# Altium schematic sheet 1-Connectors.SchDoc(1-Connectors)
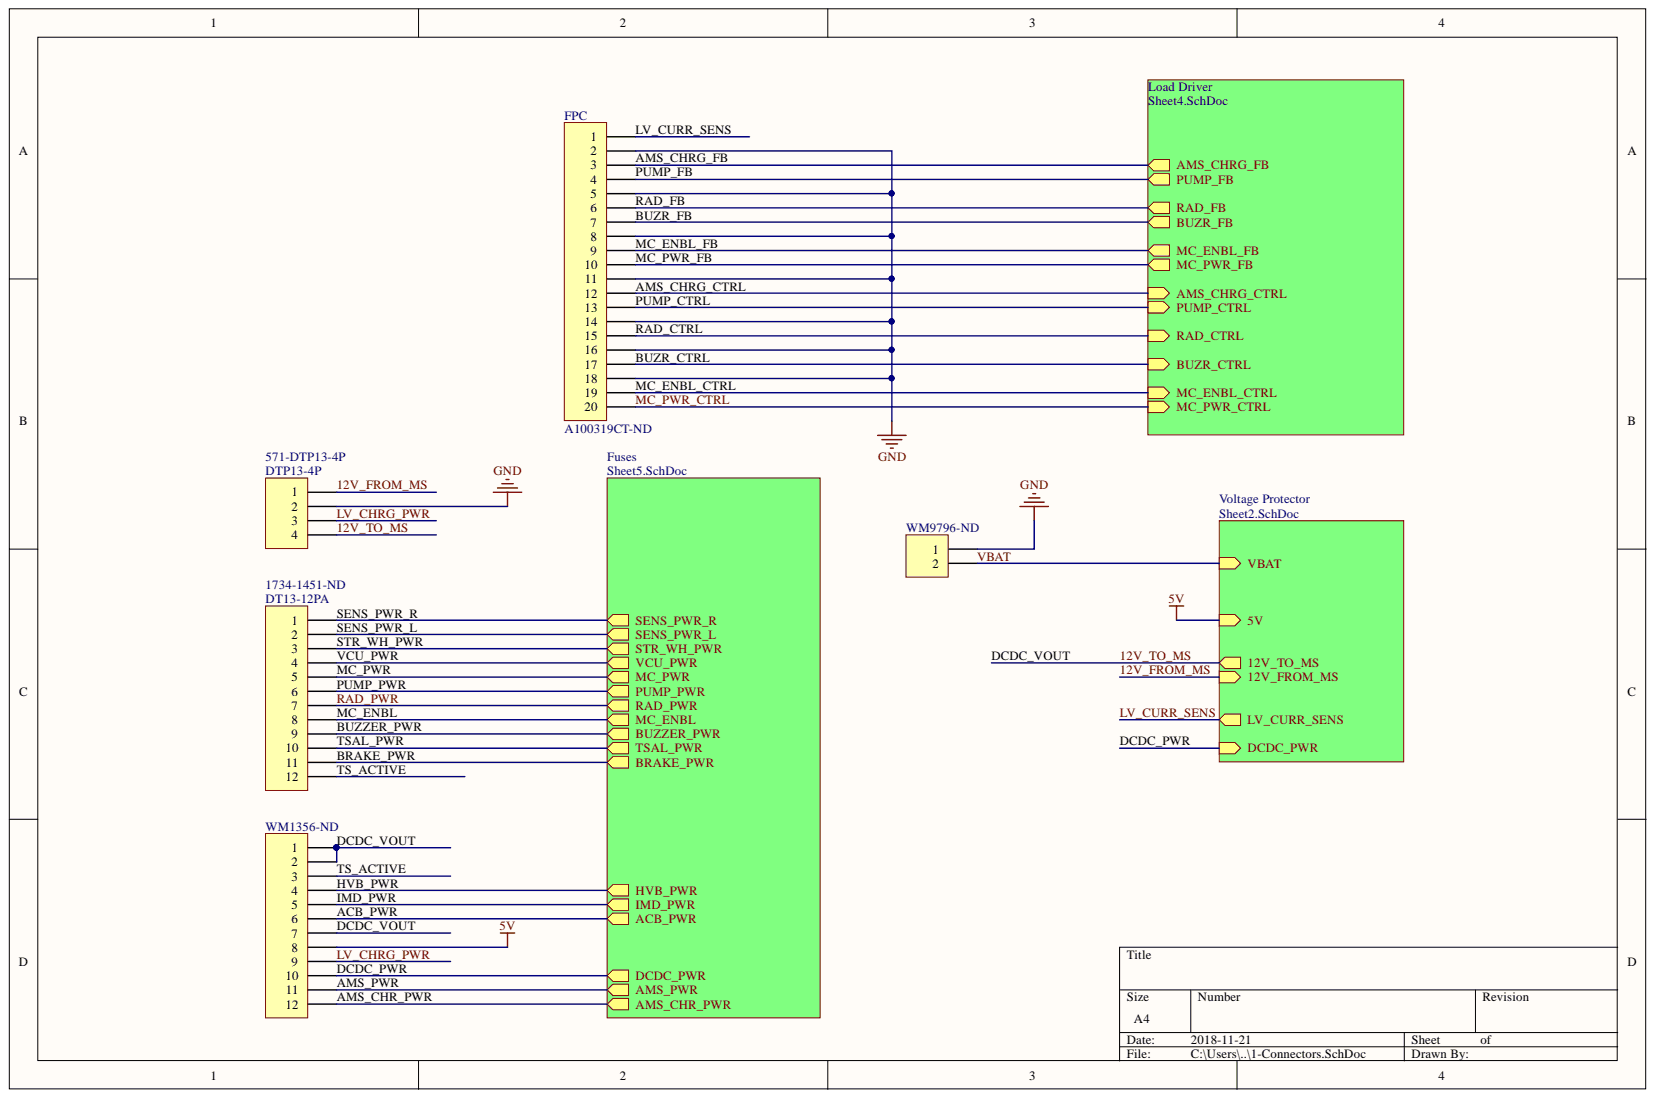
[diagram p0: 1-Connectors.SchDoc]

## Parts
DT13-12PA  DT13-12PA  Supplier Part Number 2: 1734-1451-ND  [DT13-12PA]
DTP13-4P  DTP13-4P  Supplier Part Number 1: 571-DTP13-4P  [DTP13-4P]
FPC  Header 20  [A100319CT-ND]  (value not drawn)
WM1356-ND  Header 12  [WM1356-ND]  (value not drawn)
WM9796-ND  WM9796-ND  [WM9796-ND]

## Nets
5V: WM1356-ND.8
GND: DTP13-4P.2 FPC.2 FPC.5 FPC.8 FPC.11 FPC.14 FPC.16 FPC.18 WM9796-ND.1
12V_FROM_MS: DTP13-4P.1
12V_TO_MS: DTP13-4P.4 WM1356-ND.1 WM1356-ND.2 WM1356-ND.7
ACB_PWR: WM1356-ND.6
AMS_CHR_PWR: WM1356-ND.12
AMS_CHRG_CTRL: FPC.12
AMS_CHRG_FB: FPC.3
AMS_PWR: WM1356-ND.11
BRAKE_PWR: DT13-12PA.11
BUZR_CTRL: FPC.17
BUZR_FB: FPC.7
BUZZER_PWR: DT13-12PA.9
DCDC_PWR: WM1356-ND.10
HVB_PWR: WM1356-ND.4
IMD_PWR: WM1356-ND.5
LV_CHRG_PWR: DTP13-4P.3 WM1356-ND.9
LV_CURR_SENS: FPC.1
MC_ENBL: DT13-12PA.8
MC_ENBL_CTRL: FPC.19
MC_ENBL_FB: FPC.9
MC_PWR: DT13-12PA.5
MC_PWR_CTRL: FPC.20
MC_PWR_FB: FPC.10
PUMP_CTRL: FPC.13
PUMP_FB: FPC.4
PUMP_PWR: DT13-12PA.6
RAD_CTRL: FPC.15
RAD_FB: FPC.6
RAD_PWR: DT13-12PA.7
SENS_PWR_L: DT13-12PA.2
SENS_PWR_R: DT13-12PA.1
STR_WH_PWR: DT13-12PA.3
TS_ACTIVE: DT13-12PA.12 WM1356-ND.3
TSAL_PWR: DT13-12PA.10
VBAT: WM9796-ND.2
VCU_PWR: DT13-12PA.4

## Library data of the parts
DT13-12PA WM1356-ND = Header 12: Header, 12-Pin; Supplier 1: Digi-Key; Library Name: Power_PCB.SCHLIB; LatestRevisionNote: Re-released for DXP Platform.; LatestRevisionDate: 17-Jul-2002; Component Kind: Standard; Publisher: Altium Limited
  DT13-12PA: Supplier Part Number 1: DT13-12PA; Supplier 2: Digi-Key
  WM1356-ND: Supplier Part Number 1: WM1356-ND
DTP13-4P = Header 4: Header, 4-Pin; Supplier 1: Mouser; Library Name: Miscellaneous Connectors.IntLib; LatestRevisionNote: Re-released for DXP Platform.; LatestRevisionDate: 17-Jul-2002; Component Kind: Standard; Publisher: Altium Limited
FPC = Header 20: Header, 20-Pin; Supplier Part Number 1: A100319CT-ND; Supplier 1: Digi-Key; Library Name: Miscellaneous Connectors.IntLib; LatestRevisionNote: Re-released for DXP Platform.; LatestRevisionDate: 17-Jul-2002; Component Kind: Standard; Publisher: Altium Limited
WM9796-ND = Header 2: Header, 2-Pin; Supplier Part Number 2: WM9796-ND; Supplier 2: Digi-Key; Supplier 1: Digi-Key; Library Name: Miscellaneous Connectors.IntLib; LatestRevisionNote: Re-released for DXP Platform.; LatestRevisionDate: 17-Jul-2002; Component Kind: Standard; Publisher: Altium Limited
